# Altium schematic sheet PowerSupply.SchDoc(PowerSupply)
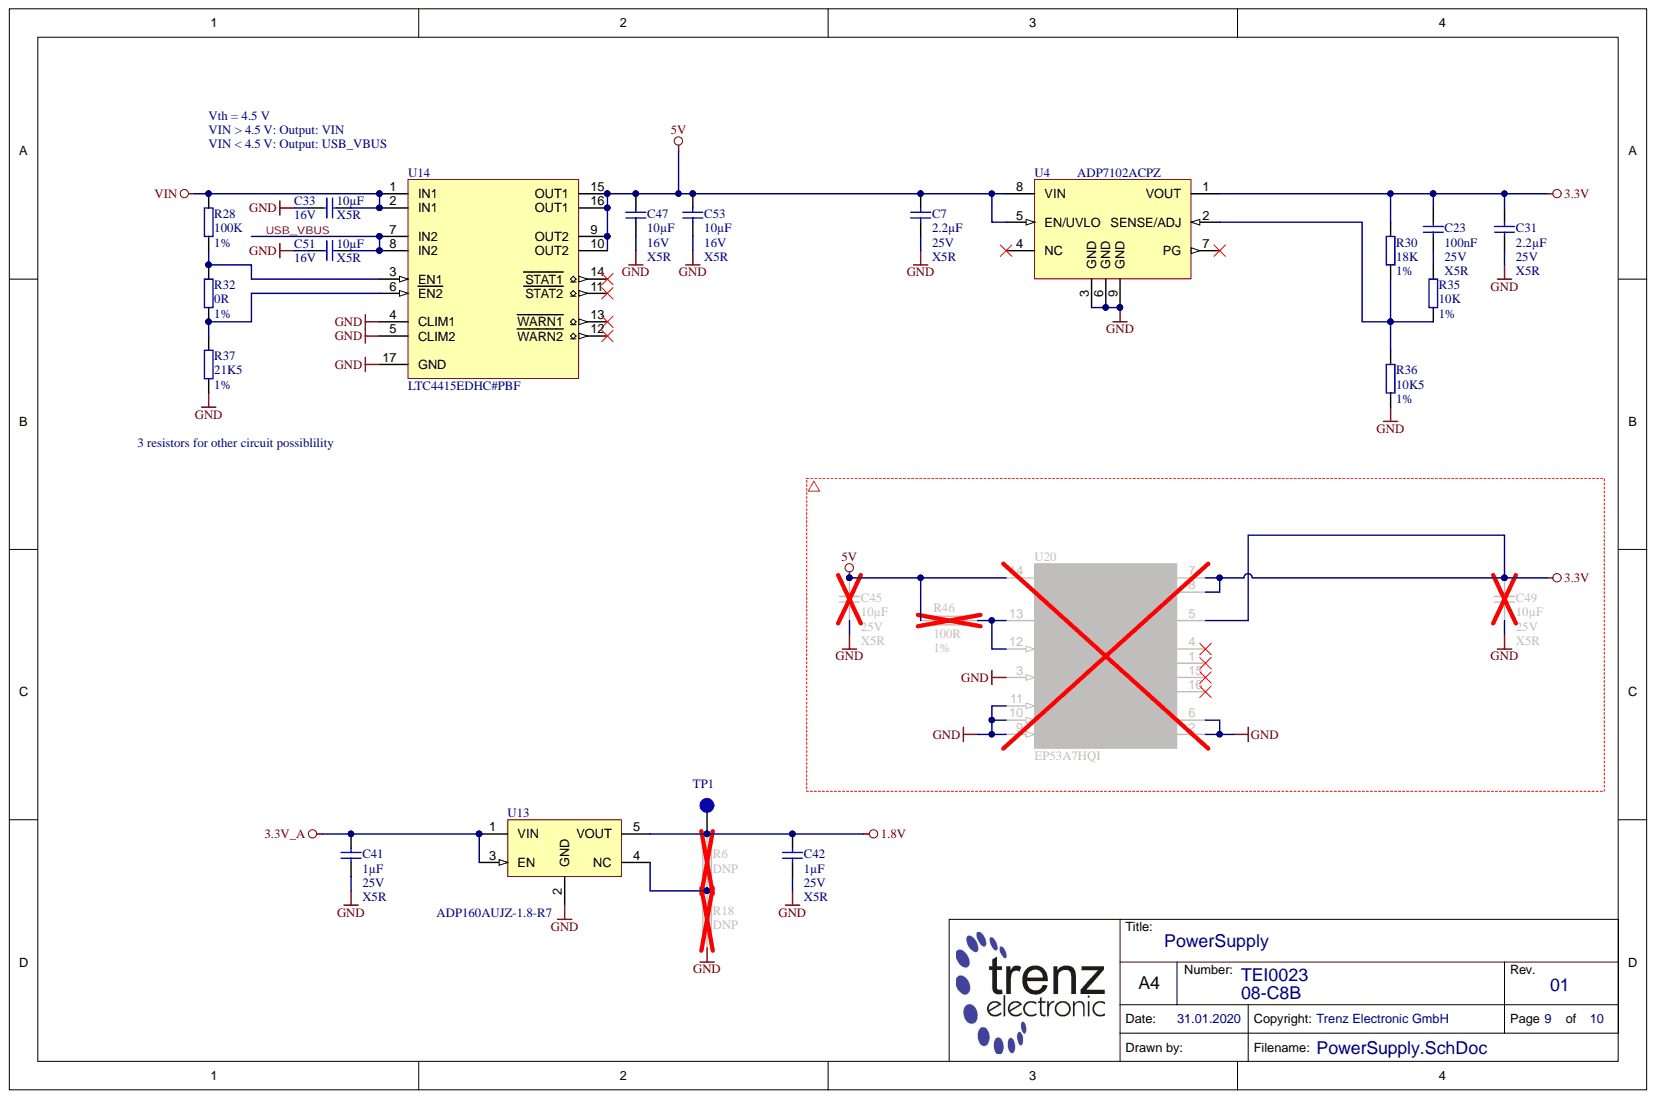
[diagram p0: PowerSupply.SchDoc]

## Parts
C7  2.2µF  Voltage: 25V  Technology: X5R  [CAPC1608X90N]
C23  100nF  Voltage: 25V  [CAPC1005X55N]
C31  2.2µF  Voltage: 25V  Technology: X5R  [CAPC1608X90N]
C33  10µF  Voltage: 16V  Technology: X5R  [CAPC1608X90N]
C41  1µF  Voltage: 25V  Technology: X5R  [CAPC1608X90N]
C42  1µF  Voltage: 25V  Technology: X5R  [CAPC1608X90N]
C45  10µF  Voltage: 25V  Technology: X5R  [CAPC2012X135N]
C47  10µF  Voltage: 16V  Technology: X5R  [CAPC1608X90N]
C49  10µF  Voltage: 25V  Technology: X5R  [CAPC2012X135N]
C51  10µF  Voltage: 16V  Technology: X5R  [CAPC1608X90N]
C53  10µF  Voltage: 16V  Technology: X5R  [CAPC1608X90N]
R6  DNP  [RESC1005X40N]  (no BOM)
R18  DNP  [RESC1005X40N]  (no BOM)
R28  100K  Tolerance: 1%  [RESC1005X40N]
R30  18K  Tolerance: 1%  [RESC1005X40N]
R32  0R  Tolerance: 1%  [RESC1005X40N]
R35  10K  Tolerance: 1%  [RESC1005X40N]
R36  10K5  Tolerance: 1%  [RESC1005X40N]
R37  21K5  Tolerance: 1%  [RESC1005X40N]
R46  100R  Tolerance: 1%  [RESC1005X40N]
TP1  Testpoint 0.8mm  [TP_0.8mm]  (value not drawn)
U4  ADP7102ACPZ  [SON50P300X300X80-9N]
U13  ADP160AUJZ-1.8-R7  [SOT95P280X100-5N]
U14  LTC4415EDHC#PBF  [LTC4415ED]
U20  EP53A7HQI  [EP53F8QI]

## Nets
1.8V: C42.1 R6.2 TP1.1 U13.5(VOUT)
3.3V: C23.1 C31.1 C49.1 R30.1 U4.1(VOUT) U20.5(VSENSE) U20.7(VOUT) U20.8(VOUT)
3.3V_A: C41.1 U13.1(VIN) U13.3(EN)
5V: C7.1 C45.1 C47.2 C53.2 R46.1 U4.5(EN/UVLO) U4.8(VIN) U14.9(OUT2) U14.10(OUT2) U14.15(OUT1) U14.16(OUT1) U20.14(PVIN)
GND: C7.2 C31.2 C33.1 C41.2 C42.2 C45.2 C47.1 C49.2 C51.1 C53.1 R18.1 R36.2 R37.2 U4.3(GND) U4.6(GND) U4.9(GND) U13.2(GND) U14.4(CLIM1) U14.5(CLIM2) U14.17(GND) U20.2(PGND) U20.3(LLM) U20.6(AGND) U20.9(VS2) U20.10(VS1) U20.11(VS0)
VIN: C33.2 R28.1 U14.1(IN1) U14.2(IN1)
USB_VBUS: C51.2 U14.7(IN2) U14.8(IN2)
NetC23_2: C23.2 R35.1
NetR6_1: R6.1 R18.2 U13.4(NC)
NetR28_2: R28.2 R32.1 U14.3(EN1)
NetR30_2: R30.2 R35.2 R36.1 U4.2(SENSE/ADJ)
NetR32_2: R32.2 R37.1 U14.6(EN2)
NetR46_2: R46.2 U20.12(ENABLE) U20.13(AVIN)

Not connected: U4 4(NC) 7(PG); U14 11(STAT2) 12(WARN2) 13(WARN1) 14(STAT1); U20 1(NC(SW)) 4(NC) 15(NC(SW)) 16(NC(SW))

## Library data of the parts
C7 C31 = 27910: KON 2.2uF 25V X5R ±10% SMD BF 1608 (0603); Value: 2.2u; Temperature-Range: -55/+85; Mixed: SMT; Database Table Name: C_1608_0603; Tolerance: ±10%; Library Name: TE.DbLib; Component Kind: Standard; Case: 1608 (0603); AN: 27910
C23 = 22472: KON 100nF 25V X5R ±10% SMD BF 1005 (0402); Value: 100n; Temperature-Range: -55/+85; Preferred: Yes; Mixed: SMT; Database Table Name: C_1005_0402; Technology: X5R; Library Name: TE.DbLib; Component Kind: Standard; Case: 1005 (0402); AN: 22472
C33 C47 C51 C53 = 25341: KON 10µF 16V X5R 20% SMD BF 1608 (0603); Value: 10u; Temperature-Range: -55/+85; Preferred: Yes; Mixed: SMT; Database Table Name: C_1608_0603; Tolerance: 20%; Library Name: TE.DbLib; Component Kind: Standard; Case: 1608 (0603); AN: 25341
C41 C42 = 26837: KON 1µF 25V X5R ±10% SMD BF 1608 (0603); Value: 1u; Temperature-Range: -55/+85; Preferred: Yes; Mixed: SMT; Database Table Name: C_1608_0603; Tolerance: 10%; Library Name: TE.DbLib; Component Kind: Standard; Case: 1608 (0603); AN: 26837
C45 C49 = 25567: KON 10µF 25V X5R 10% SMD BF 2012 (0805); Value: 10u; Temperature-Range: -55/+85; Mixed: SMT; Database Table Name: C_2012_0805; Tolerance: 10%; Library Name: TE.DbLib; Component Kind: Standard; Case: 2012 (0805); AN: 25567
R6 R18 = 29416: WID SMD BF 1005 (0402) DNP; Database Table Name: R_1005_0402; Value: DNP; Library Name: TE.DbLib; Case: 1005 (0402); AN: 29416
R28 = 22558: WID SMD 100K 1% 0,063W  BF 1005 (0402); Preferred: Yes; Mixed: SMT; H-ART: RC0402FR-13100KL; Database Table Name: R_1005_0402; Value: 100K; Technology: TK100; Library Name: TE.DbLib; Component Kind: Standard; Case: 1005 (0402); AN: 22558
R30 = 24875: WID SMD 18K 1% 0,063W  BF 1005 (0402); Preferred: Yes; Mixed: SMT; Database Table Name: R_1005_0402; Value: 18K; Technology: TK100; Library Name: TE.DbLib; Component Kind: Standard; Case: 1005 (0402); AN: 24875
R32 = 22738: WID SMD 0R  BF 1005 (0402); Value: 0; Preferred: Yes; Mixed: SMT; H-ART: RC0402JR-070RL; Database Table Name: R_1005_0402; Technology: TK100; Library Name: TE.DbLib; Component Kind: Standard; Case: 1005 (0402); AN: 22738
R35 = 22750: WID SMD 10K 1% 0,063W BF 1005 (0402); Preferred: Yes; Mixed: SMT; H-ART: RC0402FR-0710KL; Database Table Name: R_1005_0402; Value: 10K; Technology: TK100; Library Name: TE.DbLib; Component Kind: Standard; Case: 1005 (0402); AN: 22750
R36 = 30130: WID SMD 10K5 1% 0,063W  BF 1005 (0402); Voltage: 0.063W; Value: 10.5K; Mixed: SMT; Database Table Name: R_1005_0402; Technology: TK100; Library Name: TE.DbLib; Component Kind: Standard; Case: 1005 (0402); AN: 30130
R37 = 26071: WID SMD 21K5 1% 0,063W BF 1005 (0402); Value: 21.5K; Mixed: SMT; Database Table Name: R_1005_0402; Technology: TK100; Library Name: TE.DbLib; Component Kind: Standard; Case: 1005 (0402); AN: 26071
R46 = 22507: WID SMD 100R 1% 0,063W  BF 1005 (0402); Value: 100; Preferred: Yes; Mixed: SMT; H-ART: RC0402FR-13100RL; Database Table Name: R_1005_0402; Technology: TK100; Library Name: TE.DbLib; Component Kind: Standard; Case: 1005 (0402); AN: 22507
TP1 = 26289: Mixed: MECH; Database Table Name: PCB; Library Name: TE.DbLib; Description: Testpoint 0.8mm; Component Kind: Standard; AN: 26289
U4 = 24611: ADP7102ACPZ-R7; Trenz-Stock: Yes; Mixed: SMT; Database Table Name: LREG; Library Name: TE.DbLib; H-ART: ADP7102ACPZ-R7; Component Kind: Standard; Case: DFN8; AN: 24611; HelpURL: B:\AD\adlib\datasheet\ADP7102
U13 = 28939: Voltage: 1.8V; Mixed: SMT; Database Table Name: LREG; Library Name: TE.DbLib; H-ART: ADP160AUJZ-1.8-R7; Description: ADP160AUJZ-1.8-R7; Component Kind: Standard; Case: SOT23-5; AN: 28939; HelpURL: b:\AD\adlib\datasheet\ADP16x\
U14 = 30127: Temperature-Range: 0/+85; Mixed: SMT; Database Table Name: SWITCH; Storage-Temperature: -65/+150(Abs); Library Name: TE.DbLib; H-ART: LTC4415EDHC#PBF; Description: LTC4415EDHC#PBF; Component Kind: Standard; Case: DFN16; AN: 30127; HelpURL: b:\AD\adlib\datasheet\AnalogDevices\LTC4415\
U20 = 27996: Trenz-Stock: Yes; Temperature-Range: -40/+85; Mixed: SMT; Database Table Name: DCDC; Preferred: Yes; Library Name: TE.DbLib; H-ART: EP53A7HQI; Description: EP53A7HQI; Component Kind: Standard; Case: QFN16; AN: 27996; HelpURL: B:\AD\adlib\datasheet\EP53A7HQI
